# Altium schematic sheet Sheet4.SchDoc(Sheet4)
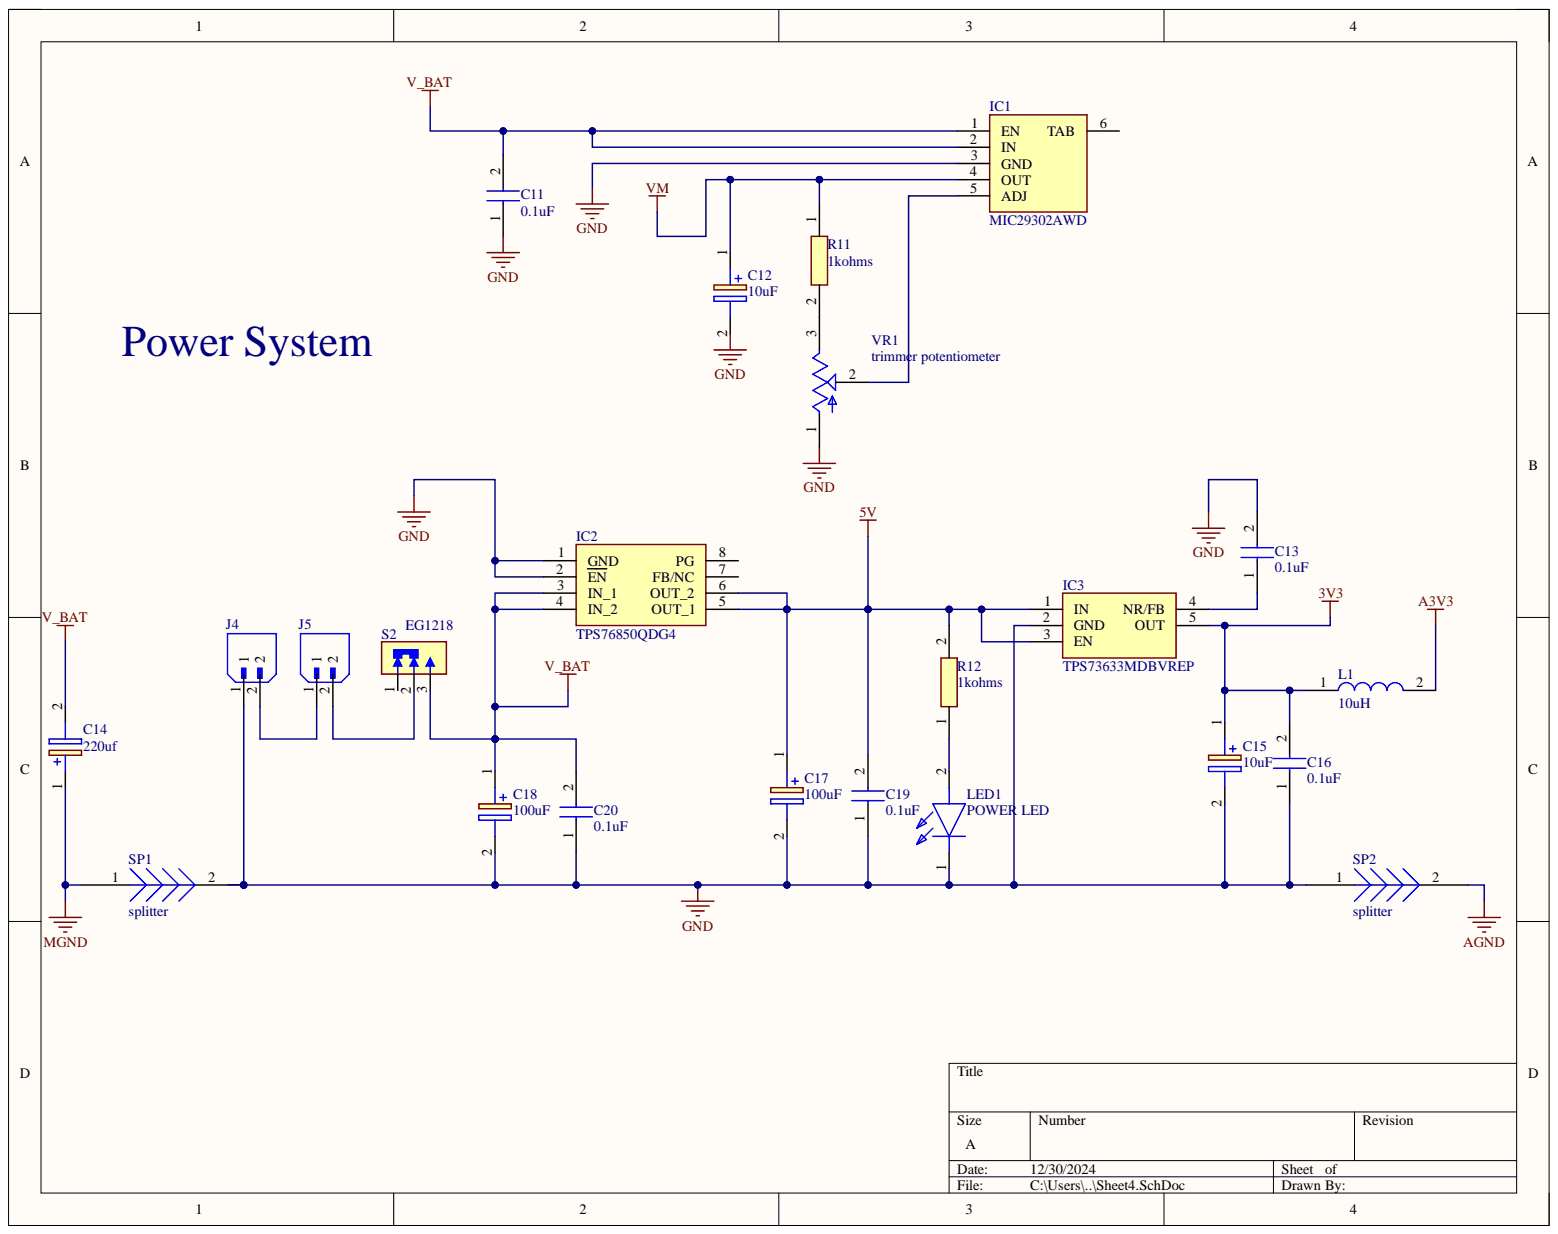
[diagram p0: Sheet4.SchDoc]

## Parts
C11  0.1uF  [CAPC1005X55N]
C12  10uF  [CAPPM3216X180N]
C13  0.1uF  [CAPC1005X55N]
C14  220uf  [CAPPM7343X430N]
C15  10uF  [CAPPM3216X180N]
C16  0.1uF  [CAPC1005X55N]
C17  100uF  [CAPPM3528X210N]
C18  100uF  [CAPPM3528X210N]
C19  0.1uF  [CAPC1005X55N]
C20  0.1uF  [CAPC1005X55N]
IC1  MIC29302AWD  [MIC29302AWD]
IC2  TPS76850QDG4  [SOIC127P600X175-8N]
IC3  TPS73633MDBVREP  [SOT95P280X145-5N]
J4  2 pin JST  [JST_B2B-XH-A_(LF)(SN)]  (value not drawn)
J5  2 pin JST  [JST_B2B-XH-A_(LF)(SN)]  (value not drawn)
L1  10uH  [INDC3216X130N]
LED1  POWER LED  [LEDC1608X45N]
R11  1kohms  [RESC1005X40N]
R12  1kohms  [RESC1005X40N]
S2  EG1218  [SW_EG1218]
SP1  splitter  [splitter]  (no BOM)
SP2  splitter  [splitter]  (no BOM)
VR1  trimmer potentiometer  [TRIM_3362P-1-253LF]

## Nets
3V3: C15.1 C16.2 IC3.5(OUT) L1.1
5V: C17.1 C19.2 IC2.5(OUT_1) IC2.6(OUT_2) IC3.1(IN) IC3.3(EN) R12.2
A3V3: L1.2
AGND: SP2.2
GND: C11.1 C12.2 C13.2 C15.2 C16.1 C17.2 C18.2 C19.1 C20.1 IC1.3(GND) IC2.1(GND) IC2.2(EN) IC3.2(GND) J4.1 LED1.1 SP1.2 SP2.1 VR1.1
MGND: C14.1 SP1.1
V_BAT: C11.2 C14.2 C18.1 C20.2 IC1.1(EN) IC1.2(IN) IC2.3(IN_1) IC2.4(IN_2) S2.3
VM: C12.1 IC1.4(OUT) R11.1
NetC13_1: C13.1 IC3.4(NR/FB)
NetIC1_5: IC1.5(ADJ) VR1.2
NetJ4_2: J4.2 J5.1
NetJ5_2: J5.2 S2.2
NetLED1_2: LED1.2 R12.1
NetR11_2: R11.2 VR1.3

Not connected: IC1 6(TAB); IC2 7(FB/NC) 8(PG); S2 1

## Library data of the parts
C11 = 0.1uF: 0.1uf SMD 25V cermaic; Manufacturer_Name: TAIYO YUDEN; Manufacturer_Part_Number: MBAST105SB7104KFNA01; Component Kind: Standard; Datasheet Link: https://ds.yuden.co.jp/TYCOMPAS/or/specSheet?pn=MBAST105SB7104KFNA01; Height: 0.55mm; Library Name: Schlib1.SchLib; Mouser Part Number: 963-BAST105SB7104KF; Mouser Price/Stock: https://www.mouser.co.uk/ProductDetail/TAIYO-YUDEN/MBAST105SB7104KFNA01?qs=tlsG%2FOw5FFj0FpJuqULGiw%3D%3D
C12 C15 = 10uF: 10uF Tantalum  SMD  5% 10VDC; Manufacturer_Name: Vishay; Manufacturer_Part_Number: TR3A106J010C2000; Component Kind: Standard; Datasheet Link: http://www.vishay.com/doc?40080; Height: 1.8mm; Library Name: Schlib1.SchLib; Mouser Part Number: 74-TR3A106J010C2000; Mouser Price/Stock: https://www.mouser.com/Search/Refine.aspx?Keyword=74-TR3A106J010C2000
C13 C16 C19 C20 = MBAST105SB7104KFNA01: 0.1uf SMD 25V cermaic; Manufacturer_Name: TAIYO YUDEN; Component Kind: Standard; Datasheet Link: https://ds.yuden.co.jp/TYCOMPAS/or/specSheet?pn=MBAST105SB7104KFNA01; Height: 0.55mm; Library Name: Schlib1.SchLib; Manufacturer_Part_Number: MBAST105SB7104KFNA01; Mouser Part Number: 963-BAST105SB7104KF; Mouser Price/Stock: https://www.mouser.co.uk/ProductDetail/TAIYO-YUDEN/MBAST105SB7104KFNA01?qs=tlsG%2FOw5FFj0FpJuqULGiw%3D%3D
C14 = 220uf  cap: 10% 10VDC tantalum solidCapacitor Polarised; Manufacturer_Name: Vishay; Manufacturer_Part_Number: TH3E227K010C0500; Component Kind: Standard; Datasheet Link: http://www.vishay.com/doc?40084; Height: 4.3mm; Library Name: Schlib1.SchLib
C17 C18 = 100uF: 100uF SMD 10% 10VDC cap; Manufacturer_Name: Kyocera AVX; Manufacturer_Part_Number: TAJB107K010RNJV; Component Kind: Standard; Datasheet Link: http://datasheets.avx.com/TAJ.pdf; Height: 2.1mm; Library Name: Schlib1.SchLib; Mouser Part Number: 581-TAJB107K010RNJV; Mouser Price/Stock: https://www.mouser.co.uk/ProductDetail/KYOCERA-AVX/TAJB107K010RNJV?qs=81r%252BiQLm7BQ7CnPsjcMucg%3D%3D
IC1 = MIC29302AWD: Integrated Circuit; Manufacturer_Name: Microchip; Arrow Part Number: MIC29302AWD; Arrow Price/Stock: https://www.arrow.com/en/products/mic29302awd/microchip-technology?region=nac; Component Kind: Standard; Datasheet Link: http://www.microchip.com/mymicrochip/filehandler.aspx?ddocname=en580808; Height: 2.451mm; Library Name: Schlib1.SchLib; Manufacturer_Part_Number: MIC29302AWD; Mouser Part Number: 998-MIC29302AWD; Mouser Price/Stock: https://www.mouser.co.uk/ProductDetail/Microchip-Technology/MIC29302AWD?qs=kh6iOki%2FeLHCfDEMcJUwRg%3D%3D
IC2 = TPS76850QDG4: 5 V 1A regulator LDO Voltage Regulators Micropower LDO Reg  Texas Instruments; Arrow Part Number: TPS76850QDG4; Arrow Price/Stock: https://www.arrow.com/en/products/tps76850qdg4/texas-instruments; Component Kind: Standard; Datasheet Link: https://www.ti.com/lit/gpn/tps768; Height: 1.75mm; Library Name: Schlib1.SchLib; Manufacturer_Name: Texas Instruments; Manufacturer_Part_Number: TPS76850QDG4; Mouser Part Number: 595-TPS76850QDG4; Mouser Price/Stock: https://www.mouser.co.uk/ProductDetail/Texas-Instruments/TPS76850QDG4?qs=6zVL%252ByCp0mokNYV0o%2FmL3Q%3D%3D
IC3 = TPS73633MDBVREP: 3.3V regulator LDO Voltage Regulators Mil Enh Cap-Free NMOS 400-mA LDO Reg; Manufacturer_Name: Texas Instruments; Arrow Part Number: TPS73633MDBVREP; Arrow Price/Stock: https://www.arrow.com/en/products/tps73633mdbvrep/texas-instruments?utm_currency=USD&region=nac; Component Kind: Standard; Datasheet Link: http://www.ti.com/lit/gpn/tps73633-ep; Height: 1.45mm; Library Name: Schlib1.SchLib; Manufacturer_Part_Number: TPS73633MDBVREP; Mouser Part Number: 595-TPS73633MDBVREP; Mouser Price/Stock: https://www.mouser.co.uk/ProductDetail/Texas-Instruments/TPS73633MDBVREP?qs=7kv20MEVUli5iZKWf9hl6g%3D%3D
J4 J5 = 2 pin JST: Connector Header Through Hole 2 position 0.098 (2.50mm); MF: JST Sales; MP: B2B-XH-A (LF)(SN); Check_prices: https://www.snapeda.com/parts/B2B-XH-A%20(LF)(SN)/JST+Sales+America+Inc./view-part/?ref=eda; Component Kind: Standard; Library Name: Schlib1.SchLib; Package: None; SnapEDA_Link: https://www.snapeda.com/parts/B2B-XH-A%20(LF)(SN)/JST+Sales+America+Inc./view-part/?ref=snap
L1 = 10uH: 10uH 10% 25mA Inductor; Manufacturer_Name: Wurth Elektronik; Manufacturer_Part_Number: 7447918; Component Kind: Standard; Datasheet Link: https://componentsearchengine.com/Datasheets/2/7447918.pdf; Height: 1.3mm; Library Name: Schlib1.SchLib; Mouser Part Number: 710-7447918; Mouser Price/Stock: https://www.mouser.co.uk/ProductDetail/Wurth-Elektronik/7447918?qs=T3oQrply3y%2FV2tNxb67AfA%3D%3D
LED1 = LED: RED - LED 5mA; Manufacturer_Name: Lite-On; Manufacturer_Part_Number: LTST-C193KRKT-5A; Arrow Part Number: LTST-C193KRKT-5A; Arrow Price/Stock: https://www.arrow.com/en/products/ltst-c193krkt-5a/lite-on-technology?utm_currency=USD&region=nac; Component Kind: Standard; Datasheet Link: http://uk.rs-online.com/web/p/products/1689748; Height: 0.45mm; Library Name: Schlib1.SchLib; Mouser Part Number: 859-LTSTC193KRKT5A; Mouser Price/Stock: https://www.mouser.co.uk/ProductDetail/Lite-On/LTST-C193KRKT-5A?qs=xIkB7y1OTor17kZSHyF5tQ%3D%3D
R11 R12 = 1kohms: 1kohms SMD thin film resistor 50V 1/16W; Manufacturer_Name: Vishay; Manufacturer_Part_Number: TNPU04021K00BZEP00; Arrow Part Number: TNPU04021K00BZEP00; Arrow Price/Stock: https://www.arrow.com/en/products/tnpu04021k00bzep00/vishay?region=nac; Component Kind: Standard; Datasheet Link: https://www.vishay.com/docs/28779/tnpue3.pdf; Height: 0.4mm; Library Name: Schlib1.SchLib; Mouser Part Number: 71-TNPU04021K00BZEP0; Mouser Price/Stock: https://www.mouser.co.uk/ProductDetail/Vishay-Draloric/TNPU04021K00BZEP00?qs=Cb2nCFKsA8qoR7PlcPQHOQ%3D%3D
S2 = EG1218: Slide Switch, EG Series, SPDT, Non-Shorting, ON-ON, 200mA DC, 30VDC, PC Pin | E-Switch EG1218; Check_prices: https://www.snapeda.com/parts/EG1218/E-Switch/view-part/?ref=eda; Component Kind: Standard; Library Name: Schlib1.SchLib; MF: E-Switch; MP: EG1218; Package: None; SnapEDA_Link: https://www.snapeda.com/parts/EG1218/E-Switch/view-part/?ref=snap
VR1 = trimmer potentiometer: 10 kOhms 0.5W, 1/2W PC Pins Through Hole Trimmer Potentiometer Cermet 1.0 Turn Top Adjustment; MF: Bourns; MP: 3362P-1-253LF; Availability: In Stock; Check_prices: https://www.snapeda.com/parts/3362P-1-253LF/Bourns/view-part/?ref=eda; Component Kind: Standard; Library Name: Schlib1.SchLib; Package: 3362P-1 Bourns Inc.; Price: None; Purchase-URL: https://pricing.snapeda.com/search/part/3362P-1-253LF/?ref=eda; SnapEDA_Link: https://www.snapeda.com/parts/3362P-1-253LF/Bourns/view-part/?ref=snap
SP1 SP2 = splitter: Library Name: Schlib1.SchLib
